# Altium schematic sheet Main.SchDoc
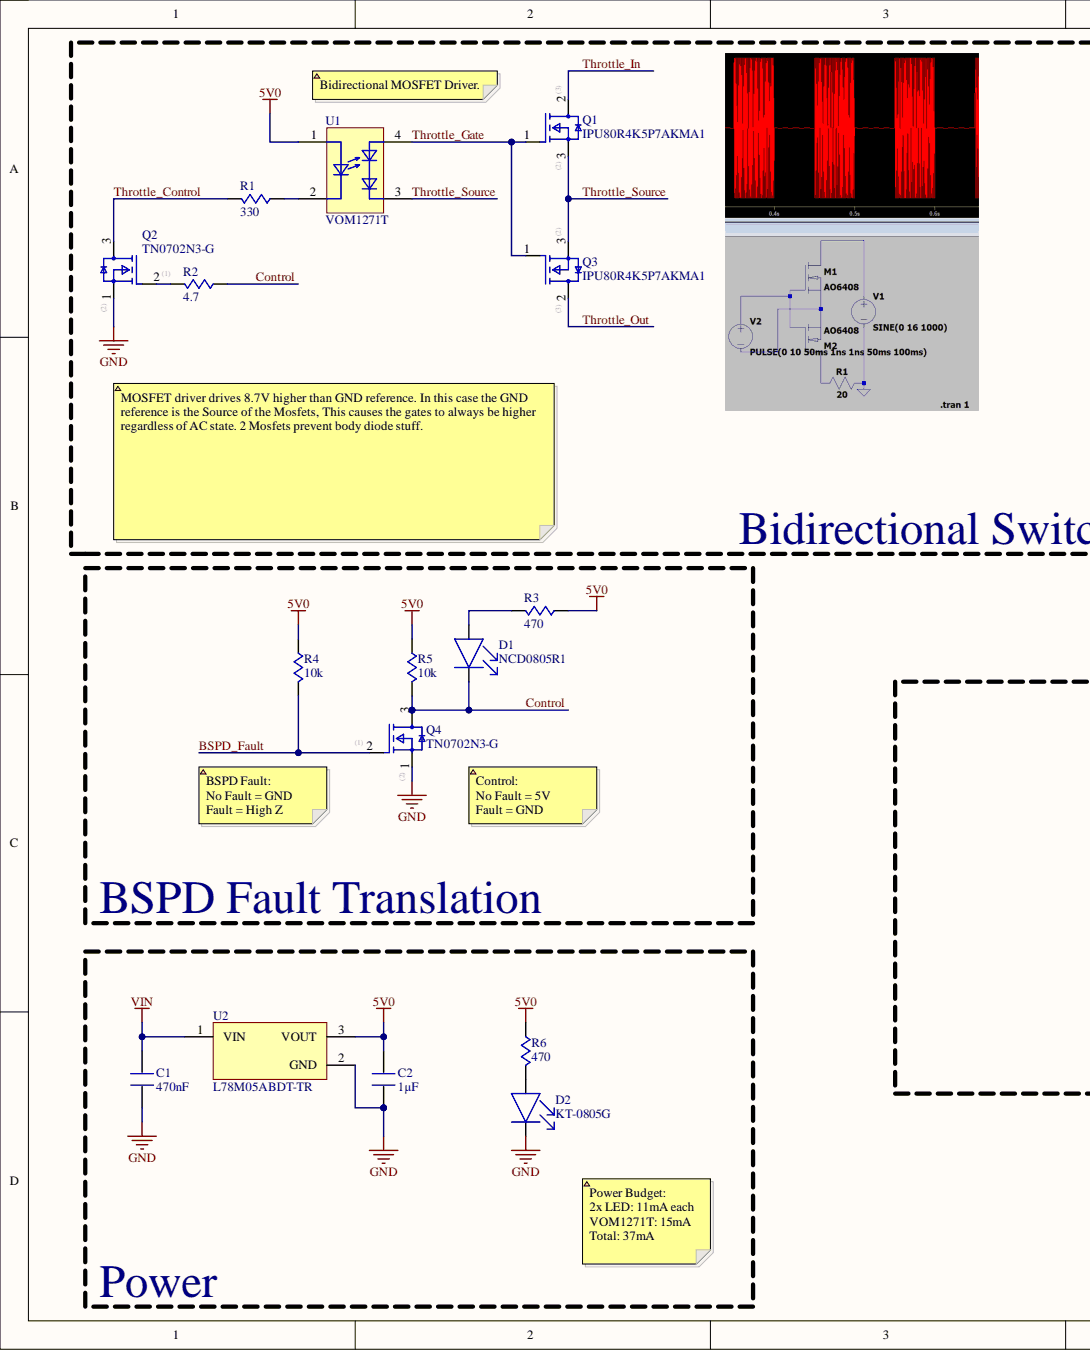
[diagram p0: Main.SchDoc, left]
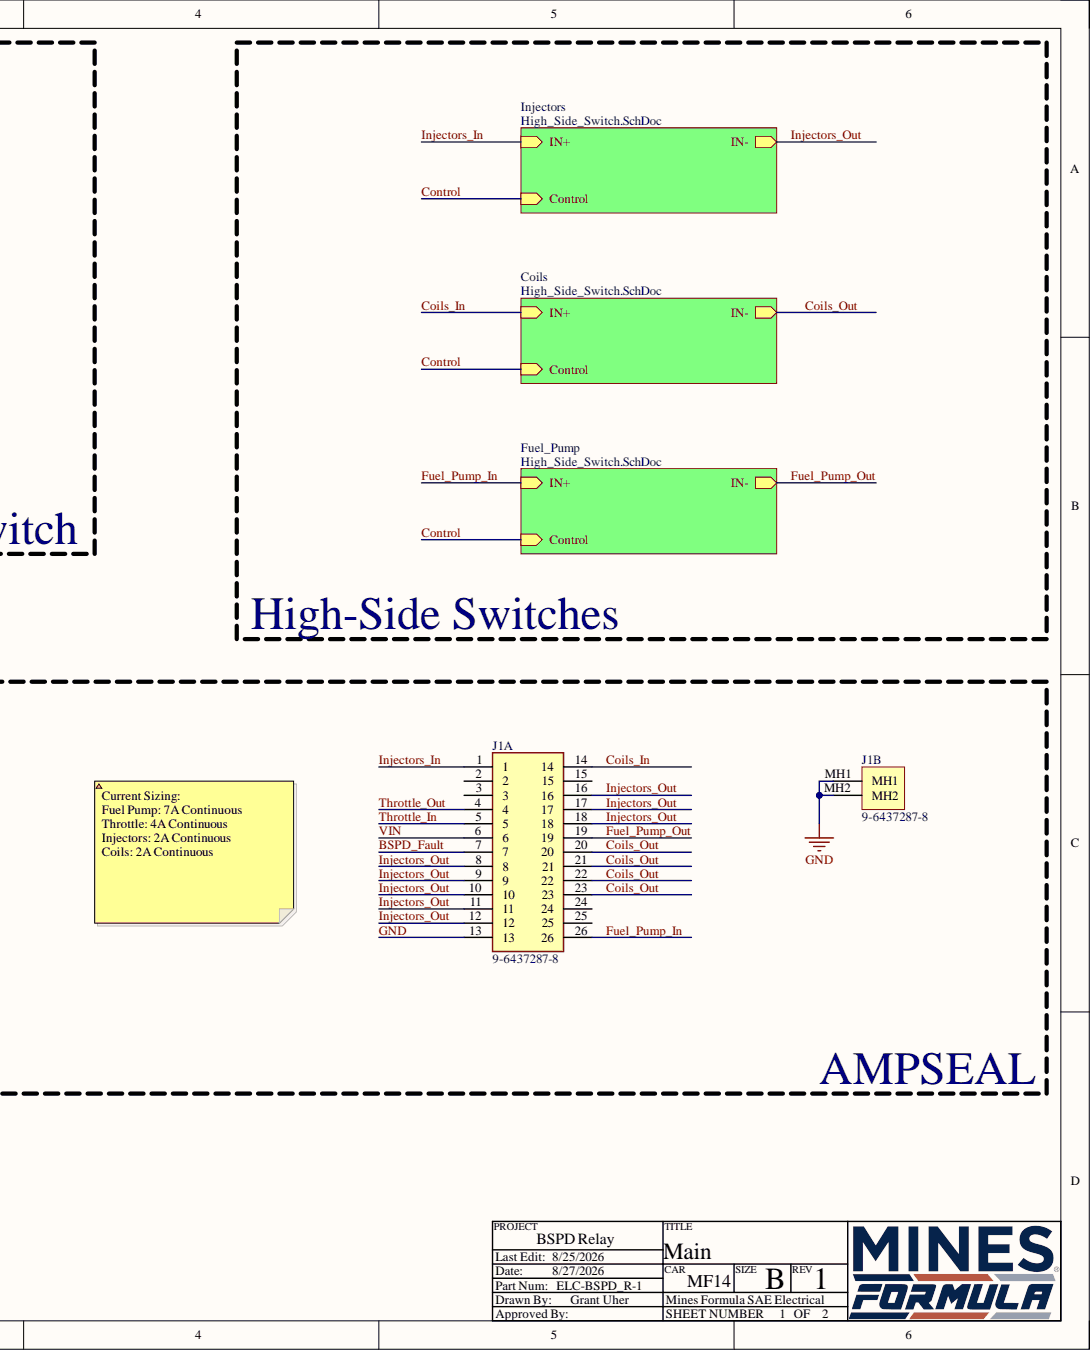
[diagram p1: Main.SchDoc, right]

## Parts
C1  value 470nF  [CAP1206]  (hidden comment: CL31B474KBHNNNE)
C2  value 1µF  [CAP1206]  (hidden comment: CL31B105KBHNNNE)
D1  NCD0805R1  [0805_Led]
D2  KT-0805G  [0805_Led]
J1  9-6437287-8  [9-6437287-8-Footprint-1]  (parts A,B)
Q1  IPU80R4K5P7AKMA1  [FP-PG-TO251-3-MFG]
Q2  TN0702N3-G  [FP-TN0702N3-G-MFG]
Q3  IPU80R4K5P7AKMA1  [FP-PG-TO251-3-MFG]
Q4  TN0702N3-G  [FP-TN0702N3-G-MFG]
R1  value 330  [RES1206]  (hidden comment: 1206W4F3300T5E)
R2  value 4.7  [RES1206]  (hidden comment: 1206W4F470KT5E)
R3  value 470  [RES1206]  (hidden comment: 1206W4F4700T5E)
R4  value 10k  [RES1206]  (hidden comment: 1206W4F1002T5E)
R5  value 10k  [RES1206]  (hidden comment: 1206W4F1002T5E)
R6  value 470  [RES1206]  (hidden comment: 1206W4F4700T5E)
U1  VOM1271T  [VOM1271T-Footprint-1]
U2  L78M05ABDT-TR  [L78M05ABDT-TR-Footprint-1]

## Nets
5V0: C2.2 R3.2 R4.2 R5.2 R6.2 U1.1 U2.3
BSPD_Fault: J1.7 Q4.1 R4.1
Coils_In: J1.14
Coils_Out: J1.20 J1.21 J1.22 J1.23
Control: D1.2 Q4.3 R2.2 R5.1
Fuel_Pump_In: J1.26
Fuel_Pump_Out: J1.19
GND: C1.1 C2.1 D2.2 J1.13 J1.MH1 J1.MH2 Q2.2 Q4.2 U2.2
Injectors_In: J1.1
Injectors_Out: J1.8 J1.9 J1.10 J1.11 J1.12 J1.16 J1.17 J1.18
Throttle_Control: Q2.3 R1.1
Throttle_Gate: Q1.1 Q3.1 U1.4
Throttle_In: J1.5 Q1.3
Throttle_Out: J1.4 Q3.3
Throttle_Source: Q1.2 Q3.2 U1.3
VIN: C1.2 J1.6 U2.1
NetD1_1: D1.1 R3.1
NetD2_1: D2.1 R6.1
NetJ1_2: J1.2
NetJ1_3: J1.3
NetJ1_15: J1.15
NetJ1_24: J1.24
NetJ1_25: J1.25
NetQ2_1: Q2.1 R2.1
NetR1_2: R1.2 U1.2

## Library data of the parts
C1 = CMP-001-00124-1: 470nF 50V X7R ±10% 1206 Multilayer Ceramic Capacitors MLCC - SMD/SMT ROHS; Manufacturer: Samsung Electro-Mechanics; Component Category: Simple; Capacitor Type: X7R; Case/Package: 1206; Component Kind: Standard; JLC Basic: False; JLC Economic: True; JLC ID: C71084; Max Operating Temperature: 125°C; Min Operating Temperature: -55°C; Mounting Technology: SMT; RoHS: True; Tolerance: 10%; Voltage Rating: 50V; Library Name: Americas - Colorado School of Mines - Mines Formula SAE
C2 = CMP-001-00113-1: 1uF 50V X7R ±10% 1206 Multilayer Ceramic Capacitors MLCC - SMD/SMT ROHS; Manufacturer: Samsung Electro-Mechanics; Component Category: Simple; Capacitor Type: 1uF; Case/Package: 1206; Component Kind: Standard; JLC Basic: True; JLC Economic: True; JLC ID: C1848; Max Operating Temperature: 125°C; Min Operating Temperature: -55°C; Mounting Technology: SMT; RoHS: True; Tolerance: 10%; Voltage Rating: 50V; Library Name: Americas - Colorado School of Mines - Mines Formula SAE
D1 = CMP-007-00044-2: -30℃~+85℃ 1.6V~2.6V 130° 195mcd 25mA 615nm~630nm 630nm 65mW Discrete Diode Red 0805 LED Indication - Discrete ROHS; Manufacturer: Foshan NationStar Optoelectronics; Component Category: Semi-Complex; Forward Voltage: 2.6V; Voltage Rating: -5V; Case/Package: 0805; Color: Red; Component Kind: Standard; Forward Current: 25mA; JLC Basic: True; JLC Economic: True; JLC ID: C84256; Max Operating Temperature: 85°C; Max Power Dissipation: 65mW; Min Operating Temperature: -30°C; Mounting Technology: SMT; RoHS Compliant: True; Library Name: Americas - Colorado School of Mines - Mines Formula SAE
D2 = CMP-007-00037-5: -40℃~+85℃ 100mW 120° 2.6V~3.1V 430mcd 513nm~528nm 525nm 5mA Discrete Diode Emerald Green Water Clear 0805 LED Indication - Discrete ROHS; Manufacturer: Hubei KENTO Elec; Component Category: Semi-Complex; Forward Current: 30mA; Forward Voltage: 2.8V; Voltage Rating: 5V; Case/Package: 0805; Color: Green; Component Kind: Standard; Datasheet URL: https://jlcpcb.com/api/file/downloadByFileSystemAccessId/8639582106239348736; JLC Basic: True; JLC Economic: True; JLC ID: C2297; Max Operating Temperature: 85°C; Max Power Dissipation: 100mW; Min Operating Temperature: -35°C; Mounting Technology: SMT; Library Name: Americas - Colorado School of Mines - Mines Formula SAE
J1 = CMP-002-00080-5: SUPER SEAL Series; 26 Way Through Hole Plug Header; with Solder Termination; Manufacturer: TE Connectivity; Connector Type: Ampseal; Gender: Male; Voltage Rating: 250V; Component Kind: Standard; JLC Basic: False; JLC Economic: False; JLC ID: C591814; Max Operating Temperature: 125°C; Min Operating Temperature: -40°C; Mounting Technology: THT; Pins: 26; RoHS Compliant: True; Library Name: Americas - Colorado School of Mines - Mines Formula SAE
Q1 Q3 = CMP-013-00047-1: MOSFET N-CH 800V 1.5A TO251-3 / N-Channel 800 V 1.5A (Tc) 13W (Tc) Through Hole PG-TO251-3-21; Manufacturer: Infineon Technologies; Component Category: Semi-Complex; Transistor Type: N-Channel Enhancement; Vgs (Max): 20V; Vgs (th): 3.5V; Case/Package: TO-251; Component Kind: Standard; Current Drain: 1.5A; JLC Basic: False; JLC Economic: True; JLC ID: C3289109; Max Operating Temperature: 150°C; Min Operating Temperature: -55°C; Mounting Technology: THT; Pins: 3; Power: 13W; RoHS Compliant: True; Library Name: Americas - Colorado School of Mines - Mines Formula SAE
Q2 Q4 = CMP-013-00046-1: Trans MOSFET N-CH Si 20V 0.53A 3-Pin TO-92 Bag; Manufacturer: Microchip Tech; Component Category: Semi-Complex; Current Drain: 530mA; Power: 1W; Rds(on): 1 ohm @VGS = 5.0V, ID = 500mA; Transistor Type: N-Channel Enhancement; Vgs (th): 1V; Case/Package: TO-92-3; Component Kind: Standard; JLC Basic: False; JLC Economic: True; JLC ID: C152199; Max Operating Temperature: 150°C; Min Operating Temperature: -55°C; Mounting Technology: THT; Pins: 3; RoHS Compliant: True; Vgs (Max): 20V; Library Name: Americas - Colorado School of Mines - Mines Formula SAE
R1 = CMP-009-00261-1: -55℃~+155℃ 200V 250mW 330Ω Thick Film Resistor ±1% ±100ppm/℃ 1206 Chip Resistor - Surface Mount ROHS; Manufacturer: UNI-ROYAL(Uniroyal Elec); Component Category: Simple; Case/Package: 1206; Component Kind: Standard; JLC Basic: False; JLC Economic: True; JLC ID: C17930; Max Operating Temperature: 155°C; Min Operating Temperature: -55°C; Mounting Technology: SMT; Power: 250mW; RoHS: True; Tolerance: 1%; Voltage Rating: 200V; Library Name: Americas - Colorado School of Mines - Mines Formula SAE
R2 = CMP-009-00260-1: -55℃~+155℃ 200V 250mW 4.7Ω Thick Film Resistor ±1% ±200ppm/℃ 1206 Chip Resistor - Surface Mount ROHS; Manufacturer: UNI-ROYAL(Uniroyal Elec); Component Category: Simple; Case/Package: 1206; Component Kind: Standard; JLC Basic: False; JLC Economic: True; JLC ID: C17937; Max Operating Temperature: 155°C; Min Operating Temperature: -55°C; Mounting Technology: SMT; Power: 250mW; RoHS: True; Tolerance: 1%; Voltage Rating: 200V; Library Name: Americas - Colorado School of Mines - Mines Formula SAE
R3 R6 = CMP-009-00259-1: -55℃~+155℃ 200V 250mW 470Ω Thick Film Resistor ±1% ±100ppm/℃ 1206 Chip Resistor - Surface Mount ROHS; Manufacturer: UNI-ROYAL(Uniroyal Elec); Component Category: Simple; Case/Package: 1206; Component Kind: Standard; JLC Basic: False; JLC Economic: True; JLC ID: C4502; Max Operating Temperature: 155°C; Min Operating Temperature: -55°C; Mounting Technology: SMT; Power: 250mW; RoHS: True; Tolerance: 1%; Voltage Rating: 200V; Library Name: Americas - Colorado School of Mines - Mines Formula SAE
R4 R5 = CMP-009-00202-2: -55℃~+155℃ 10kΩ 200V 250mW Thick Film Resistor ±1% ±100ppm/℃ 1206 Chip Resistor - Surface Mount ROHS; Manufacturer: UNI-ROYAL(Uniroyal Elec); Component Category: Simple; Case/Package: 1206; Component Kind: Standard; Datasheet URL: https://www.lcsc.com/datasheet/lcsc_datasheet_2206010145_UNI-ROYAL-Uniroyal-Elec-1206W4F1002T5E_C17902.pdf; JLC Basic: True; JLC Economic: True; JLC ID: C17902; Max Operating Temperature: 155°C; Min Operating Temperature: -55°C; Mounting Technology: SMT; Power: 250mW; RoHS: True; Tolerance: 1%; Voltage Rating: 200V; Library Name: Americas - Colorado School of Mines - Mines Formula SAE
U1 = CMP-00024-00007-3: -40℃~+100℃ 1.4V 24us 4.5kV 50mA 53us SOP-4-2.54mm Solid State Relays (MOS Output) ROHS; Manufacturer: Vishay Intertech; Component Category: Complex; Nominal Supply Voltage: 2V; Voltage Rating: 0V; Case/Package: SOP-4-2.54mm; Component Kind: Standard; Datasheet URL: https://www.vishay.com/docs/83469/vom1271.pdf; JLC Basic: False; JLC Economic: True; JLC ID: C146286; Max Operating Temperature: 100°C; Min Operating Temperature: -40°C; Mounting Technology: SMT; Nominal Supply Current: 50mA; Library Name: Americas - Colorado School of Mines - Mines Formula SAE
U2 = CMP-019-00056-2: -40℃~+125℃@(Tj) 1 2V@(350mA) 35V 40uV 500mA 5V 62dB@(120Hz) 6mA Fixed Over Temperature Protection、Short Circuit Protection Positive TO-252-2(DPAK) Voltage Regulators - Linear, Low Drop Out (LDO) Regulators ROHS; Manufacturer: STMicroelectronics; Dropout Voltage: 2V; Max Power Dissipation: 500mW; Min Supply Voltage: 7V; Case/Package: TO-252-2(DPAK); Component Kind: Standard; JLC Basic: True; JLC Economic: True; JLC ID: C58069; Max Operating Temperature: 125°C; Max Supply Voltage: 35V; Min Operating Temperature: -40°C; Mounting Technology: SMT; Nominal Supply Current: 6mA; Output Current: 500mA; Output Voltage: 5V; Pins: 3; RoHS Compliant: True; Library Name: Americas - Colorado School of Mines - Mines Formula SAE
